# Altium schematic sheet CM5.SchDoc
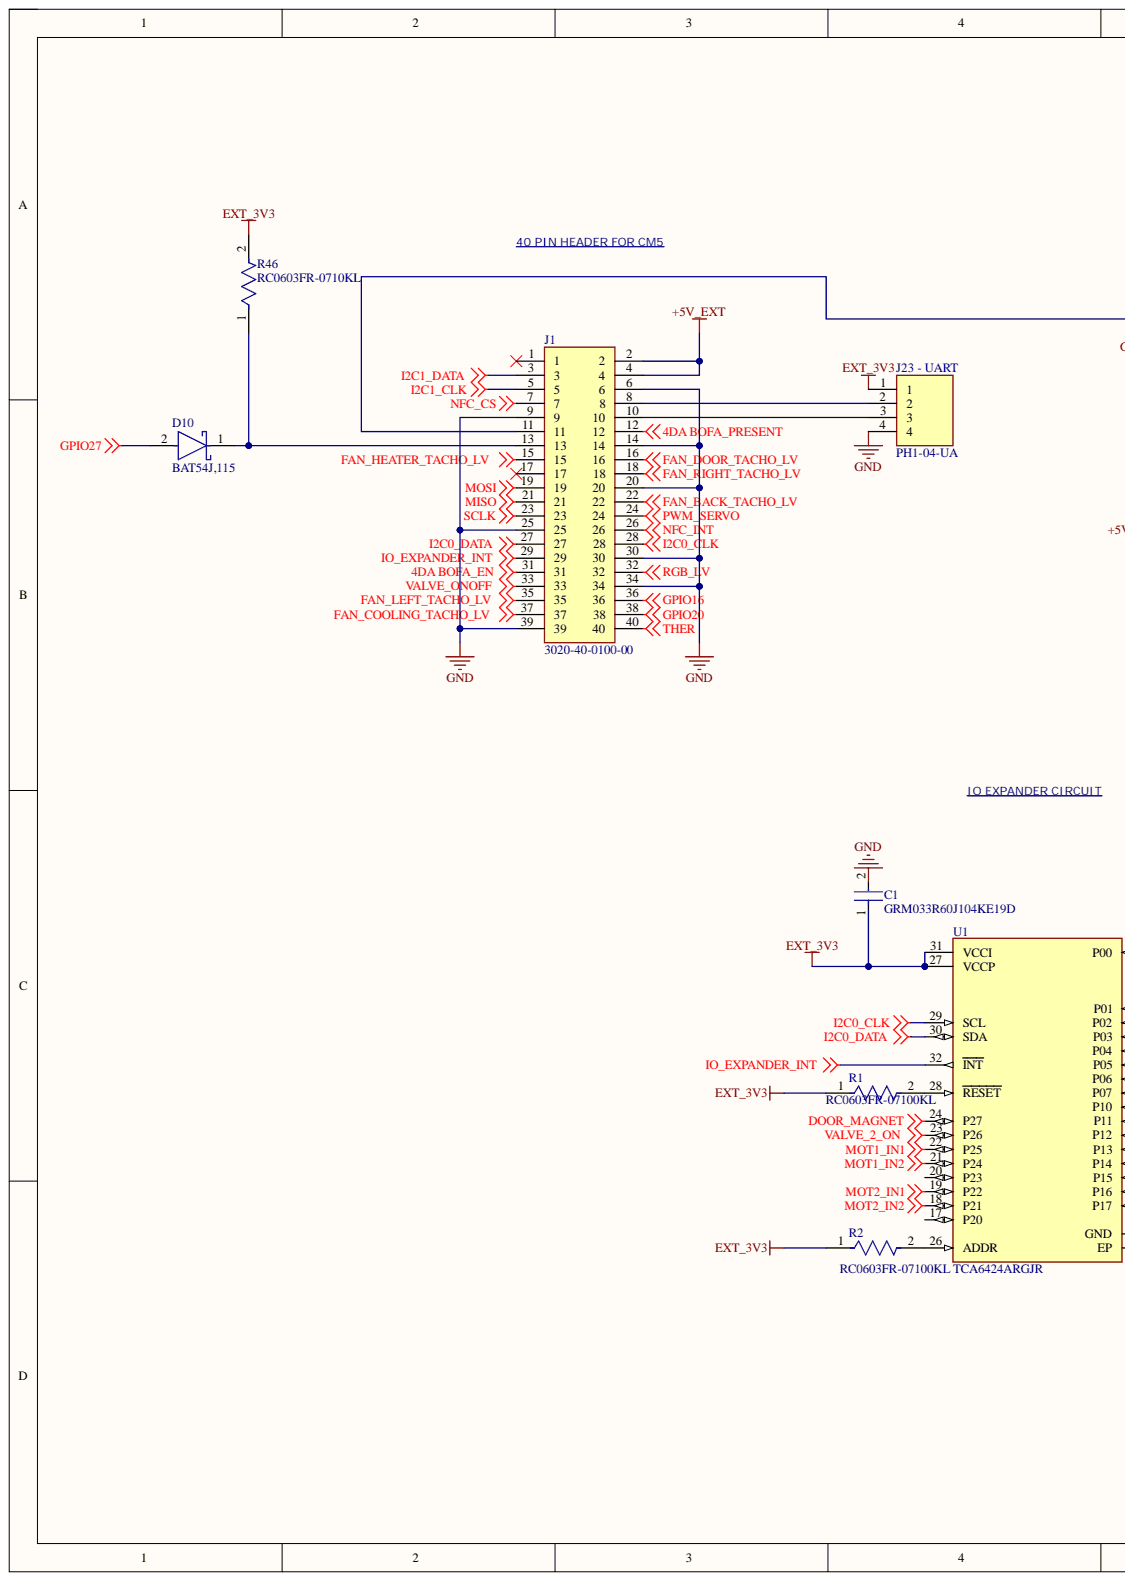
[diagram p0: CM5.SchDoc, left]
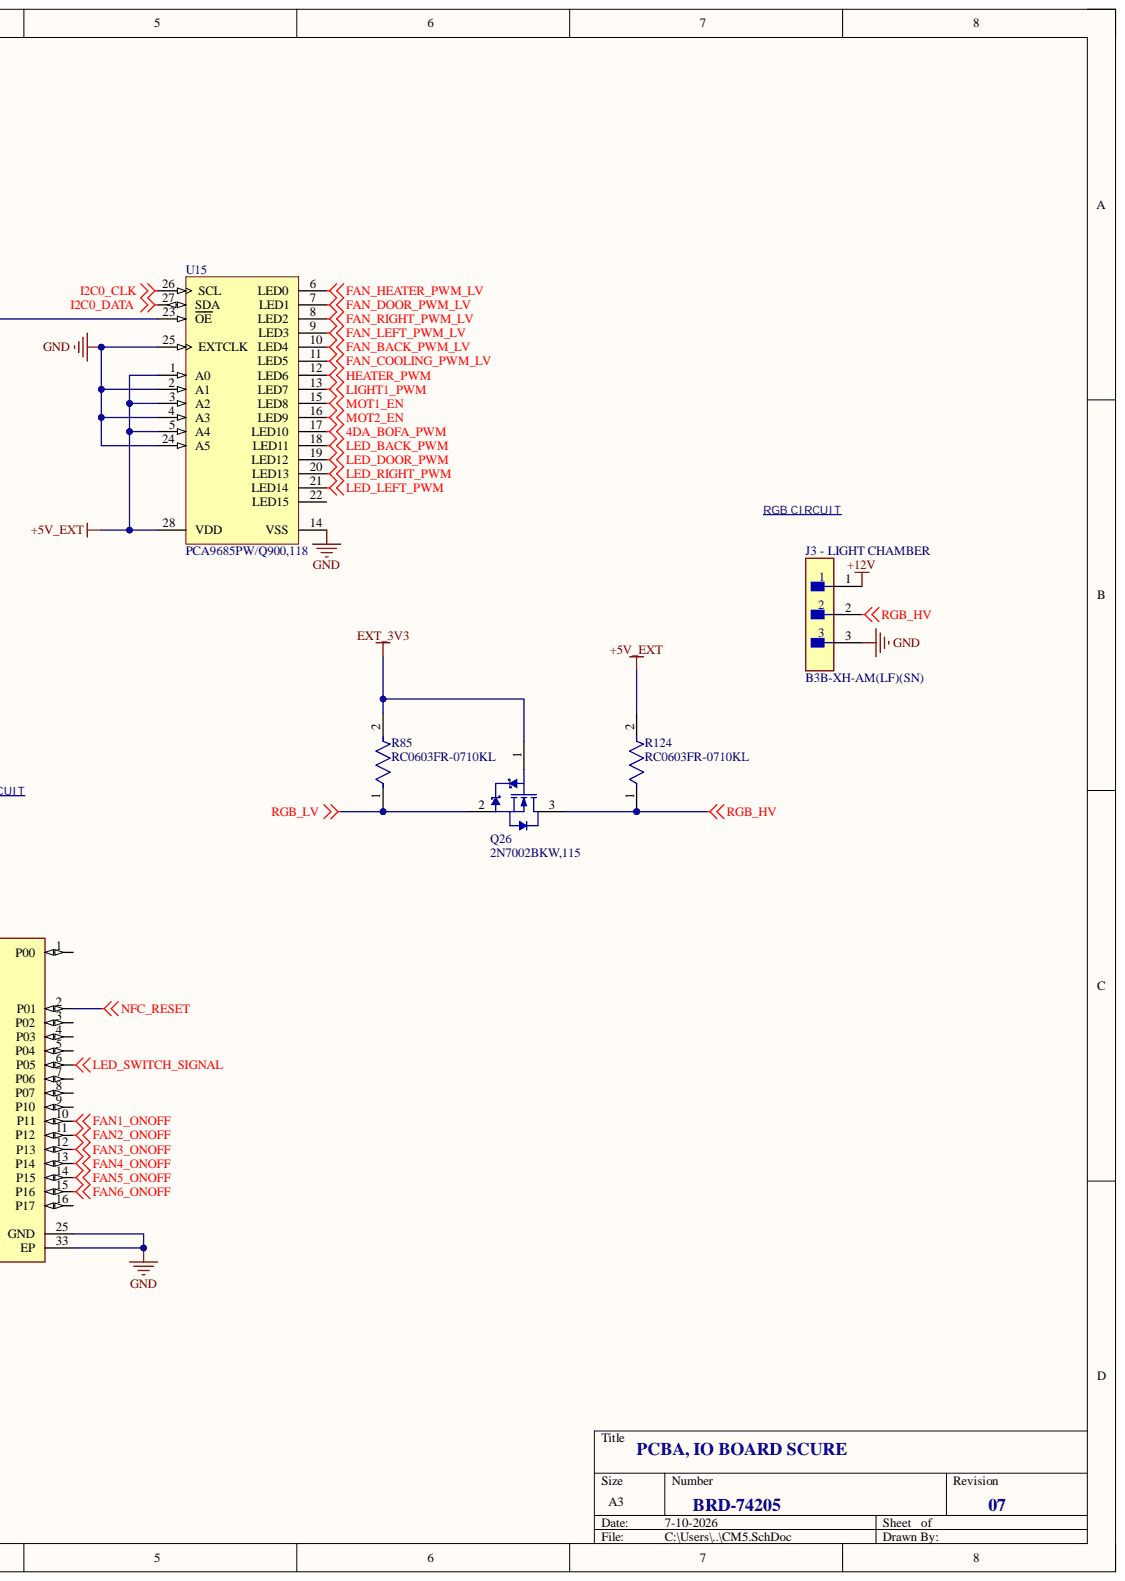
[diagram p1: CM5.SchDoc, right]

## Parts
C1  GRM033R60J104KE19D  [FP-GRM033-0_03-MFG]
D10  BAT54J,115  [FP-SOD323F-MFG]
J1  3020-40-0100-00  [302040010000]
J3_-_LIGHT_CHAMBER  B3B-XH-AM(LF)(SN)  [FP-B3B-XH-AM_LF_SN-MFG]
J23_-_UART  PH1-04-UA  [HDRV4W64P0X254_1X4_1016X250X901P]
Q26  2N7002BKW,115  [NXP-SOT323_N]
R1  RC0603FR-07100KL  [FP-RC0603-0_55-MFG]
R2  RC0603FR-07100KL  [FP-RC0603-0_55-MFG]
R46  RC0603FR-0710KL  [FP-RC0603-0_55-IPC_C]
R85  RC0603FR-0710KL  [FP-RC0603-0_55-IPC_C]
R124  RC0603FR-0710KL  [FP-RC0603-0_55-IPC_C]
U1  TCA6424ARGJR  [FP-RGJ0032A-MFG]
U15  PCA9685PW/Q900,118  [SOT361-1_V]

## Nets
4DA BOFA_EN: J1.31
4DA BOFA_PRESENT: J1.12
4DA_BOFA_PWM: U15.17(LED10)
+5V_EXT: J1.2 J1.4 R124.2 U15.1(A0) U15.3(A2) U15.5(A4) U15.28(VDD)
+12V: J3_-_LIGHT_CHAMBER.1
DOOR_MAGNET: U1.24(P27)
EXT_3V3: C1.1 J23_-_UART.1 Q26.1 R1.1 R2.1 R46.2 R85.2 U1.27(VCCP) U1.31(VCCI)
FAN1_ONOFF: U1.10(P11)
FAN2_ONOFF: U1.11(P12)
FAN3_ONOFF: U1.12(P13)
FAN4_ONOFF: U1.13(P14)
FAN5_ONOFF: U1.14(P15)
FAN6_ONOFF: U1.15(P16)
FAN_BACK_PWM_LV: U15.10(LED4)
FAN_BACK_TACHO_LV: J1.22
FAN_COOLING_PWM_LV: U15.11(LED5)
FAN_COOLING_TACHO_LV: J1.37
FAN_DOOR_PWM_LV: U15.7(LED1)
FAN_DOOR_TACHO_LV: J1.16
FAN_HEATER_PWM_LV: U15.6(LED0)
FAN_HEATER_TACHO_LV: J1.15
FAN_LEFT_PWM_LV: U15.9(LED3)
FAN_LEFT_TACHO_LV: J1.35
FAN_RIGHT_PWM_LV: U15.8(LED2)
FAN_RIGHT_TACHO_LV: J1.18
GND: C1.2 J1.6 J1.9 J1.14 J1.20 J1.25 J1.30 J1.34 J1.39 J3_-_LIGHT_CHAMBER.3 J23_-_UART.4 U1.25(GND) U1.33(EP) U15.2(A1) U15.4(A3) U15.14(VSS) U15.24(A5) U15.25(EXTCLK)
GPIO16: J1.36
GPIO20: J1.38
GPIO27: D10.2
HEATER_PWM: U15.12(LED6)
I2C0_CLK: J1.28 U1.29(SCL) U15.26(SCL)
I2C0_DATA: J1.27 U1.30(SDA) U15.27(SDA)
I2C1_CLK: J1.5
I2C1_DATA: J1.3
IO_EXPANDER_INT: J1.29 U1.32(INT)
LED_BACK_PWM: U15.18(LED11)
LED_DOOR_PWM: U15.19(LED12)
LED_LEFT_PWM: U15.21(LED14)
LED_RIGHT_PWM: U15.20(LED13)
LED_SWITCH_SIGNAL: U1.6(P05)
LIGHT1_PWM: U15.13(LED7)
MISO: J1.21
MOSI: J1.19
MOT1_EN: U15.15(LED8)
MOT1_IN1: U1.22(P25)
MOT1_IN2: U1.21(P24)
MOT2_EN: U15.16(LED9)
MOT2_IN1: U1.19(P22)
MOT2_IN2: U1.18(P21)
NFC_CS: J1.7
NFC_INT: J1.26
NFC_RESET: U1.2(P01)
PWM_SERVO: J1.24
RGB_HV: J3_-_LIGHT_CHAMBER.2 Q26.3 R124.1
RGB_LV: J1.32 Q26.2 R85.1
SCLK: J1.23
THER: J1.40
VALVE_2_ON: U1.23(P26)
VALVE_ONOFF: J1.33
NetD10_1: D10.1 J1.13 R46.1
NetJ1_8: J1.8 J23_-_UART.2
NetJ1_10: J1.10 J23_-_UART.3
NetJ1_11: J1.11 U15.23(OE)
NetR1_2: R1.2 U1.28(RESET)
NetR2_2: R2.2 U1.26(ADDR)

Not connected: J1 1 17; U1 1(P00) 3(P02) 4(P03) 5(P04) 7(P06) 8(P07) 9(P10) 16(P17) 17(P20) 20(P23); U15 22(LED15)

## Library data of the parts
C1 = CMP-06035-000062-2: Chip Multilayer Ceramic Capacitors for General Purpose, 0201, 0.10uF, X5R, 15%, 10%, 6.3V; Capacitance: 100nF; Case Code (Imperial): 0201; Case Code (Metric): 0603; Case/Package: 0201; Component Kind: Standard; Depth: 0.3mm; Dielectric: X5R; Height: 0.3mm; Lead Free: Lead Free; Length: 0.6mm; Library Name: Altium Content Vault; Material: Ceramic; Max Operating Temperature: 85°C; Min Operating Temperature: -55°C; Mount: Surface Mount; Package Quantity: 1; Packaging: Tape and Reel; Radiation Hardening: No; REACH SVHC: No SVHC; Termination: SMD/SMT; Thickness: 0.013inch; Tolerance: 10%; Voltage: 6.3V; Voltage Rating: 6.3V; Voltage Rating (DC): 6.3V; Weight: 6E-06oz; Width: 0.012inch
D10 = CMP-14398-000037-1: DIODE SCHOTTKY 30V 200MA SOD323F; Component Kind: Standard; Library Name: Altium Content Vault; RoHS Compliant: Yes
J1 = 3020-40-0100-00: Connector; Manufacturer_Name: CNC Tech; Arrow Part Number: 3020-40-0100-00; Arrow Price/Stock: https://www.arrow.com/en/products/3020-40-0100-00/cnc-tech-llc?utm_currency=USD&region=nac; Component Kind: Standard; Datasheet Link: http://cnctech.us/pdfs/3020-XX-0100-00.pdf; Height: 9.2mm; Manufacturer_Part_Number: 3020-40-0100-00
J3_-_LIGHT_CHAMBER = CMP-17438-000009-1: CONN HEADER VERT 3POS 2.5MM; Connector Type: Male Pin; Contact Resistance: 20mR; Gender: Male; Max Current Rating: 3A; Max Voltage Rating (AC): 250V; Number of Positions: 3; Pitch: 0.098inch; Color: Natural; Component Kind: Standard; Contact Material: Brass; Contact Plating: Tin; Contact Type: Male Pin; Depth: 5.75mm; Fastening Type: Detent; Housing Color: White; Housing Material: Polyamide; Lead Free: Lead Free; Length: 9.9mm; Library Name: Altium Content Vault; Max Operating Temperature: 85°C; Min Operating Temperature: -25°C; Mount: Through Hole; Number of Rows: 1; Orientation: Straight; Packaging: Bulk; Series: XH; Termination: Press-Fit
J23_-_UART = PH1-04-UA: Connector; Manufacturer_Name: Adam Tech; Arrow Part Number: PH1-04-UA; Arrow Price/Stock: https://www.arrow.com/en/products/ph1-04-ua/adam-tech?utm_currency=USD&region=nac; Component Kind: Standard; Datasheet Link: https://datasheet.datasheetarchive.com/originals/distributors/Datasheets_SAMA/22522227e000bb8d8f26a3580c1bb98b.pdf; Height: 9.008mm; Library Name: SamacSys.SchLib; Manufacturer_Part_Number: PH1-04-UA; Mouser Part Number: 737-PH1-04-UA; Mouser Price/Stock: https://www.mouser.co.uk/ProductDetail/Adam-Tech/PH1-04-UA?qs=HoCaDK9Nz5fnp3pp8iwrSQ%3D%3D
Q26 = CMP-1259-00106-1: N-Channel Trench MOSFET, 60 V, 0.31 A, -55 to 150 degC, 3-Pin SOT323, Tape and Reel; Manufacturer: NXP Semiconductors; Automotive Qualified: Y; Channel Type: N; ID [max] (A): 0.31; IDM [max] (A): 1.2; Packing: Tape & Reel; VDS [max] (V): 60; VGSth [typ] (V): 1.6; Code_JEITA: SC-70; Component Kind: Standard; ComponentLink1Description: Manufacturer URL; ComponentLink1URL: http://www.nxp.com/; ComponentLink2Description: Datasheet; ComponentLink2URL: http://www.nxp.com/documents/data_sheet/2N7002BKW.pdf; ComponentLink3Description: Package Specification; ComponentLink3URL: http://www.nxp.com/documents/outline_drawing/sot323_po.pdf; DatasheetVersion: Rev. 1; Library Name: Altium Content Vault; Mounting Technology: Surface Mount; PackageDescription: 3-Pin Small Outline Transistor Package, Body 2 x 1.25 mm, Pitch 0.65 mm; PackageReference: SOT323; PackageVersion: 06-03-16, 03/2006; PartNumber: 2N7002BKW,115
R1 R2 = CMP-2100-03662-2: RES SMD 100K OHM 1% 1/10W 0603; Max Power Dissipation: 100mW; Resistance: 100kR; Temperature Coefficient: 100ppm/°C; Voltage Rating: 50V; Working Voltage: 75V; Case Code (Imperial): 0603; Case Code (Metric): 1608; Case/Package: 0603; Component Kind: Standard; Construction: Rectangular; Depth: 0.8mm; Features: Moisture Resistant; Height: 0.45mm; Lead Free: Lead Free; Length: 1.6mm; Library Name: Altium Content Vault; Max Operating Temperature: 155°C; Military Standard: Not; Min Operating Temperature: -55°C; Number of Pins: 2; Number of Terminations: 2; Packaging: Tape and Reel; Power Rating: 100mW; REACH SVHC: No SVHC; Resistance Tolerance: 1%; Resistor Type: Thick Film; RoHS Compliant: Yes; Series: RC; Technology: THICK FILM; Termination: SMD/SMT; Tolerance: 1%; Voltage Rating (DC): 50V; Width: 0.8mm
R46 R85 R124 = CMP-2100-03664-2: RES 10K OHM 1% 1/10W 0603; Max Power Dissipation: 100mW; Resistance: 10kR; Temperature Coefficient: 100ppm/°C; Voltage Rating: 75V; Voltage Rating (DC): 50V; Case Code (Imperial): 0603; Case Code (Metric): 1608; Case/Package: 0603; Component Kind: Standard; Construction: Rectangular; Depth: 0.8mm; Features: Moisture Resistant; Height: 0.45mm; Lead Free: Lead Free; Lead Pitch: 0.3mm; Length: 1.6mm; Library Name: Altium Content Vault; Max Operating Temperature: 155°C; Military Standard: Not; Min Operating Temperature: -55°C; Number of Pins: 2; Number of Terminations: 2; Packaging: Tape and Reel; Power Rating: 100mW; Resistance Tolerance: 1%; Resistor Type: Thick Film; RoHS Compliant: Yes; Series: RC; Size Code: 0603; Termination: SMD/SMT; Tolerance: 1%; Weight: 7.1E-05oz; Width: 0.8mm
U1 = CMP-0314-00048-3: IC I/O EXPANDER I2C 24B 32UQFN; Frequency: 400kHz; Max Supply Voltage: 5.5V; Min Supply Voltage: 1.65V; Number of I/Os: 24; Output Current: 25mA; Case/Package: QFN; Component Kind: Standard; Contact Plating: Gold; Height: 0.6mm; Lead Free: Lead Free; Length: 5.15mm; Library Name: Altium Content Vault; Max Frequency: 400kHz; Max Operating Temperature: 85°C; Min Operating Temperature: -40°C; Number of Outputs: 24; Number of Pins: 32; Package Quantity: 1; Packaging: Tape and Reel; Radiation Hardening: No; REACH SVHC: No SVHC; RoHS Compliant: Yes; Series: -; Weight: 0.002116oz; Width: 5.15mm
U15 = CMP-1595-00002-2: 16-Channel, 12-Bit PWM Fm+ I2C-Bus LED Controller, 2.3 to 5.5 V, -40 to 85 degC, 28-Pin TSSOP (SOT361-1), RoHS, Tape and Reel; Frequency: 25000000 Hz; Supply Current Max: 10 mA; Supply Voltage Max: 5.5 V; Supply Voltage Min: 2.3 V; Code_JEDEC: MO-153; Component Kind: Standard; ComponentLink1Description: Manufacturer URL; ComponentLink1URL: http://www.nxp.com/; ComponentLink2Description: Datasheet; ComponentLink2URL: http://www.nxp.com/documents/data_sheet/PCA9685.pdf; ComponentLink3Description: Package Specification; ComponentLink3URL: http://www.nxp.com/documents/outline_drawing/sot361-1_po.pdf; DatasheetVersion: Rev. 4; Library Name: Altium Content Vault; Mounting Technology: Surface Mount; PackageDescription: 28-Pin Small Outline Package, Body 9.7 x 4.4 mm, Pitch 0.65 mm; PackageVersion: 02/2003; Packing: Tape and Reel; PartNumber: PCA9685; RoHS: TRUE; Temperature Max: 85 degC; Temperature Min: -40 degC
